# Altium schematic sheet 4-Connector.SchDoc(4-Connector)
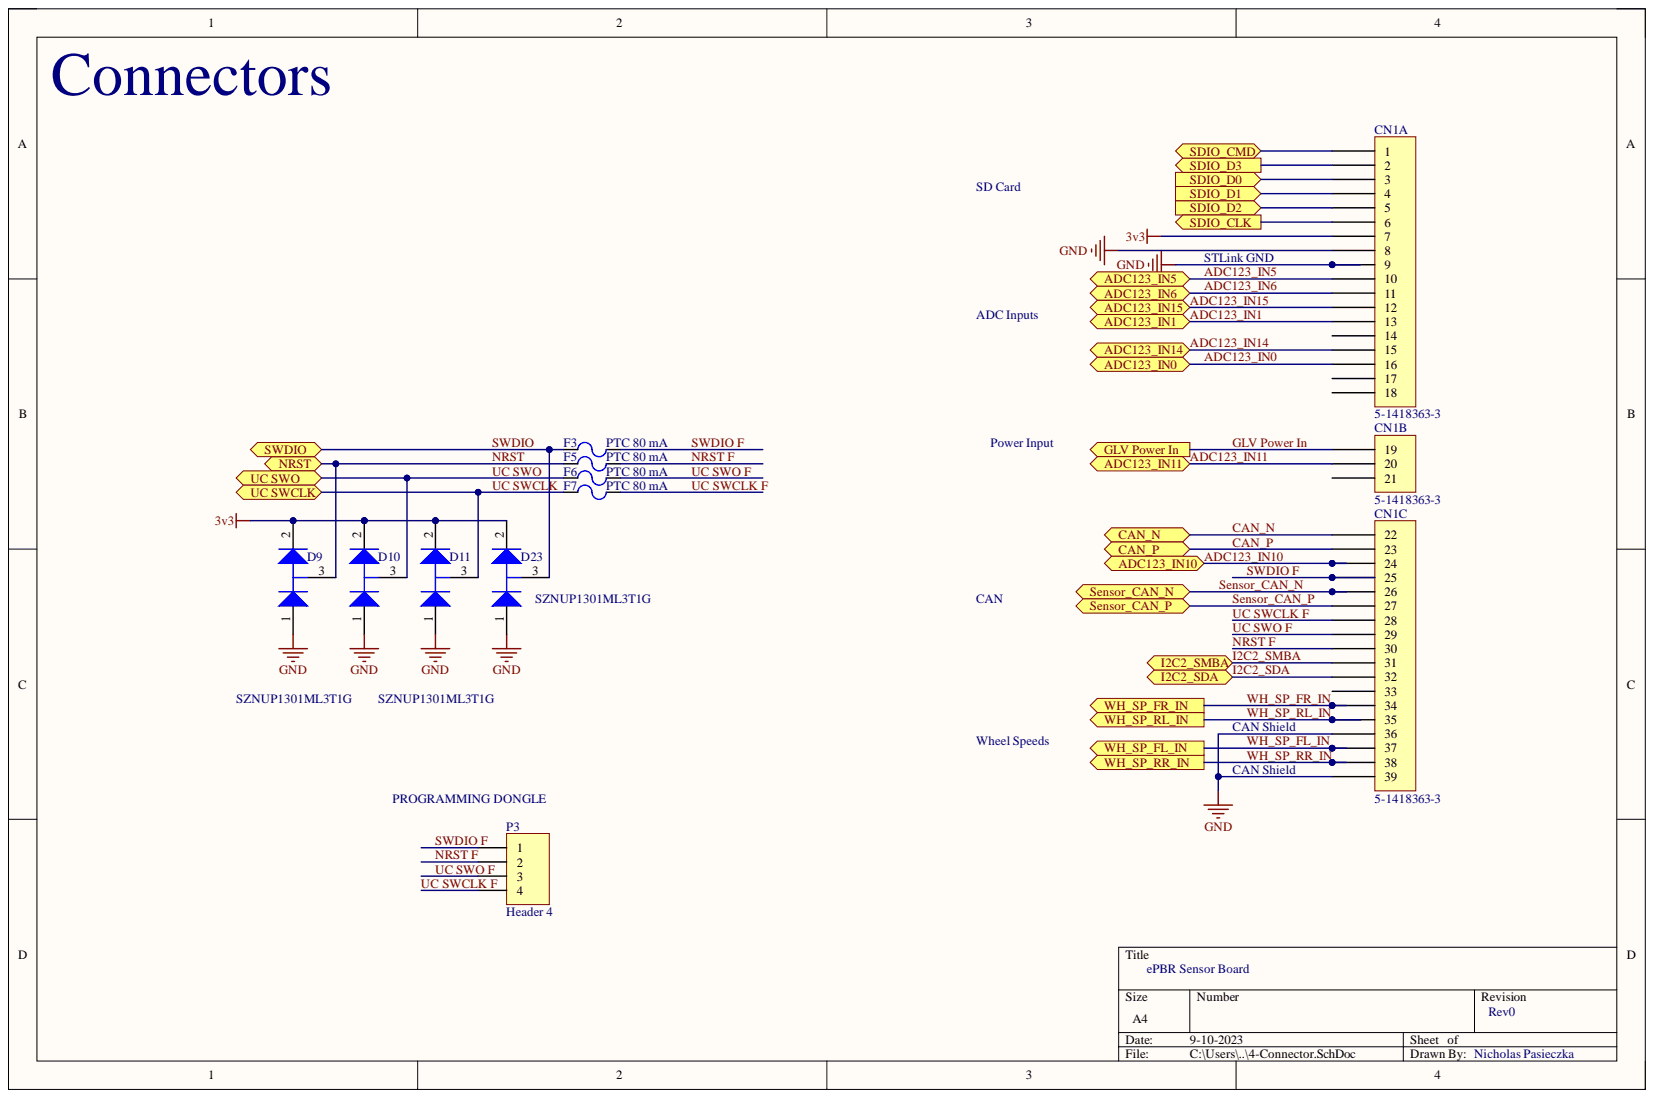
[diagram p0: 4-Connector.SchDoc]

## Parts
CN1  5-1418363-3  [5-1418363-3]  (parts A,B,C)
D9  SZNUP1301ML3T1G  [ONSC-SOT-23-3-318-08_V]
D10  SZNUP1301ML3T1G  [ONSC-SOT-23-3-318-08_V]
D11  SZNUP1301ML3T1G  [ONSC-SOT-23-3-318-08_V]
D23  SZNUP1301ML3T1G  [ONSC-SOT-23-3-318-08_V]
F3  PTC 80 mA  [0603 fuse]
F5  PTC 80 mA  [0603 fuse]
F6  PTC 80 mA  [0603 fuse]
F7  PTC 80 mA  [0603 fuse]
P3  Header 4  [HDR1X4]

## Ports
ADC123_IN0, ADC123_IN1, ADC123_IN5, ADC123_IN6, ADC123_IN10, ADC123_IN11, ADC123_IN14, ADC123_IN15, CAN_N, CAN_P, GLV Power In, I2C2_SDA, I2C2_SMBA, NRST, SDIO_CLK, SDIO_CMD, SDIO_D0, SDIO_D1, SDIO_D2, SDIO_D3, Sensor_CAN_N, Sensor_CAN_P, SWDIO, UC SWCLK, UC SWO, WH_SP_FL_IN, WH_SP_FR_IN, WH_SP_RL_IN, WH_SP_RR_IN

## Nets
3v3: CN1.7 D9.2 D10.2 D11.2 D23.2
GND: CN1.8 CN1.9 CN1.36 CN1.39 D9.1 D10.1 D11.1 D23.1
ADC123_IN0: CN1.16 ; port ADC123_IN0
ADC123_IN1: CN1.13 ; port ADC123_IN1
ADC123_IN5: CN1.10 ; port ADC123_IN5
ADC123_IN6: CN1.11 ; port ADC123_IN6
ADC123_IN10: CN1.24 ; port ADC123_IN10
ADC123_IN11: CN1.20 ; port ADC123_IN11
ADC123_IN14: CN1.15 ; port ADC123_IN14
ADC123_IN15: CN1.12 ; port ADC123_IN15
CAN_N: CN1.22 ; port CAN_N
CAN_P: CN1.23 ; port CAN_P
GLV Power In: CN1.19 ; port GLV Power In
I2C2_SDA: CN1.32 ; port I2C2_SDA
I2C2_SMBA: CN1.31 ; port I2C2_SMBA
NRST: D9.3 F5.1 ; port NRST
NRST F: CN1.30 F5.2 P3.2
SDIO_CLK: CN1.6 ; port SDIO_CLK
SDIO_CMD: CN1.1 ; port SDIO_CMD
SDIO_D0: CN1.3 ; port SDIO_D0
SDIO_D1: CN1.4 ; port SDIO_D1
SDIO_D2: CN1.5 ; port SDIO_D2
SDIO_D3: CN1.2 ; port SDIO_D3
Sensor_CAN_N: CN1.26 ; port Sensor_CAN_N
Sensor_CAN_P: CN1.27 ; port Sensor_CAN_P
SWDIO: D23.3 F3.1 ; port SWDIO
SWDIO F: CN1.25 F3.2 P3.1
UC SWCLK: D11.3 F7.1 ; port UC SWCLK
UC SWCLK F: CN1.28 F7.2 P3.4
UC SWO: D10.3 F6.1 ; port UC SWO
UC SWO F: CN1.29 F6.2 P3.3
WH_SP_FL_IN: CN1.37 ; port WH_SP_FL_IN
WH_SP_FR_IN: CN1.34 ; port WH_SP_FR_IN
WH_SP_RL_IN: CN1.35 ; port WH_SP_RL_IN
WH_SP_RR_IN: CN1.38 ; port WH_SP_RR_IN

Not connected: CN1 14 17 18 21 33

## Library data of the parts
CN1 = 5-1418363-3: Levyseal 90 degree 39 pin header; Component Kind: Standard; Library Name: VCB20.SchLib
D9-D11 D23 = CMP-1063-00171-1: Low Capacitance Diode Array for ESD Protection in a Single Data Line, 3-Pin SOT-23, Pb-Free, Tape and Reel; Manufacturer: On Semiconductor; C Max (pF): 0.9; Direction: Bidirectional; Number of Lines: 1; V(BR) Min (V): 70; Component Kind: Standard; ComponentLink1Description: Manufacturer URL; ComponentLink1URL: http://www.onsemi.com/; ComponentLink2Description: Datasheet; ComponentLink2URL: http://www.onsemi.cn/pub_link/Collateral/NUP1301ML3T1-D.PDF; ComponentLink3Description: Package Specification; ComponentLink3URL: http://www.onsemi.cn/pub_link/Collateral/318-08.PDF; DatasheetVersion: Rev. 6; Library Name: Altium Content Vault; Mounting Technology: Surface Mount; PackageDescription: 3-Pin Small Outline Transistor, Body 2.92 x 1.3 mm, Pitch 0.95 mm; PackageReference: SOT-23-3-318-08; PackageVersion: Rev. AP, 11/2009; Packing: Tape and Reel; PartNumber: SZNUP1301ML3T1G; RoHS: Pb-Free; Supplier 1: Mouser; Supplier Part Number 1: 863-SZNUP1301ML3T1G; VRWM Max (V): 70
F3 F5-F7 = PTC fuse 80mA 0ZCM0008FF2G: 0ZCM0008FF2G; Component Kind: Standard; Supplier 1: Digi-Key; Supplier Part Number 1: 507-1817-1-ND; Library Name: VCU Library.SchLib
P3 = Header 4: Header, 4-Pin; Component Kind: Standard; LatestRevisionDate: 17-Jul-2002; LatestRevisionNote: Re-released for DXP Platform.; Library Name: Miscellaneous Connectors.IntLib; Publisher: Altium Limited
